# Altium schematic sheet Schem, Transadmittance PWR.SchDoc
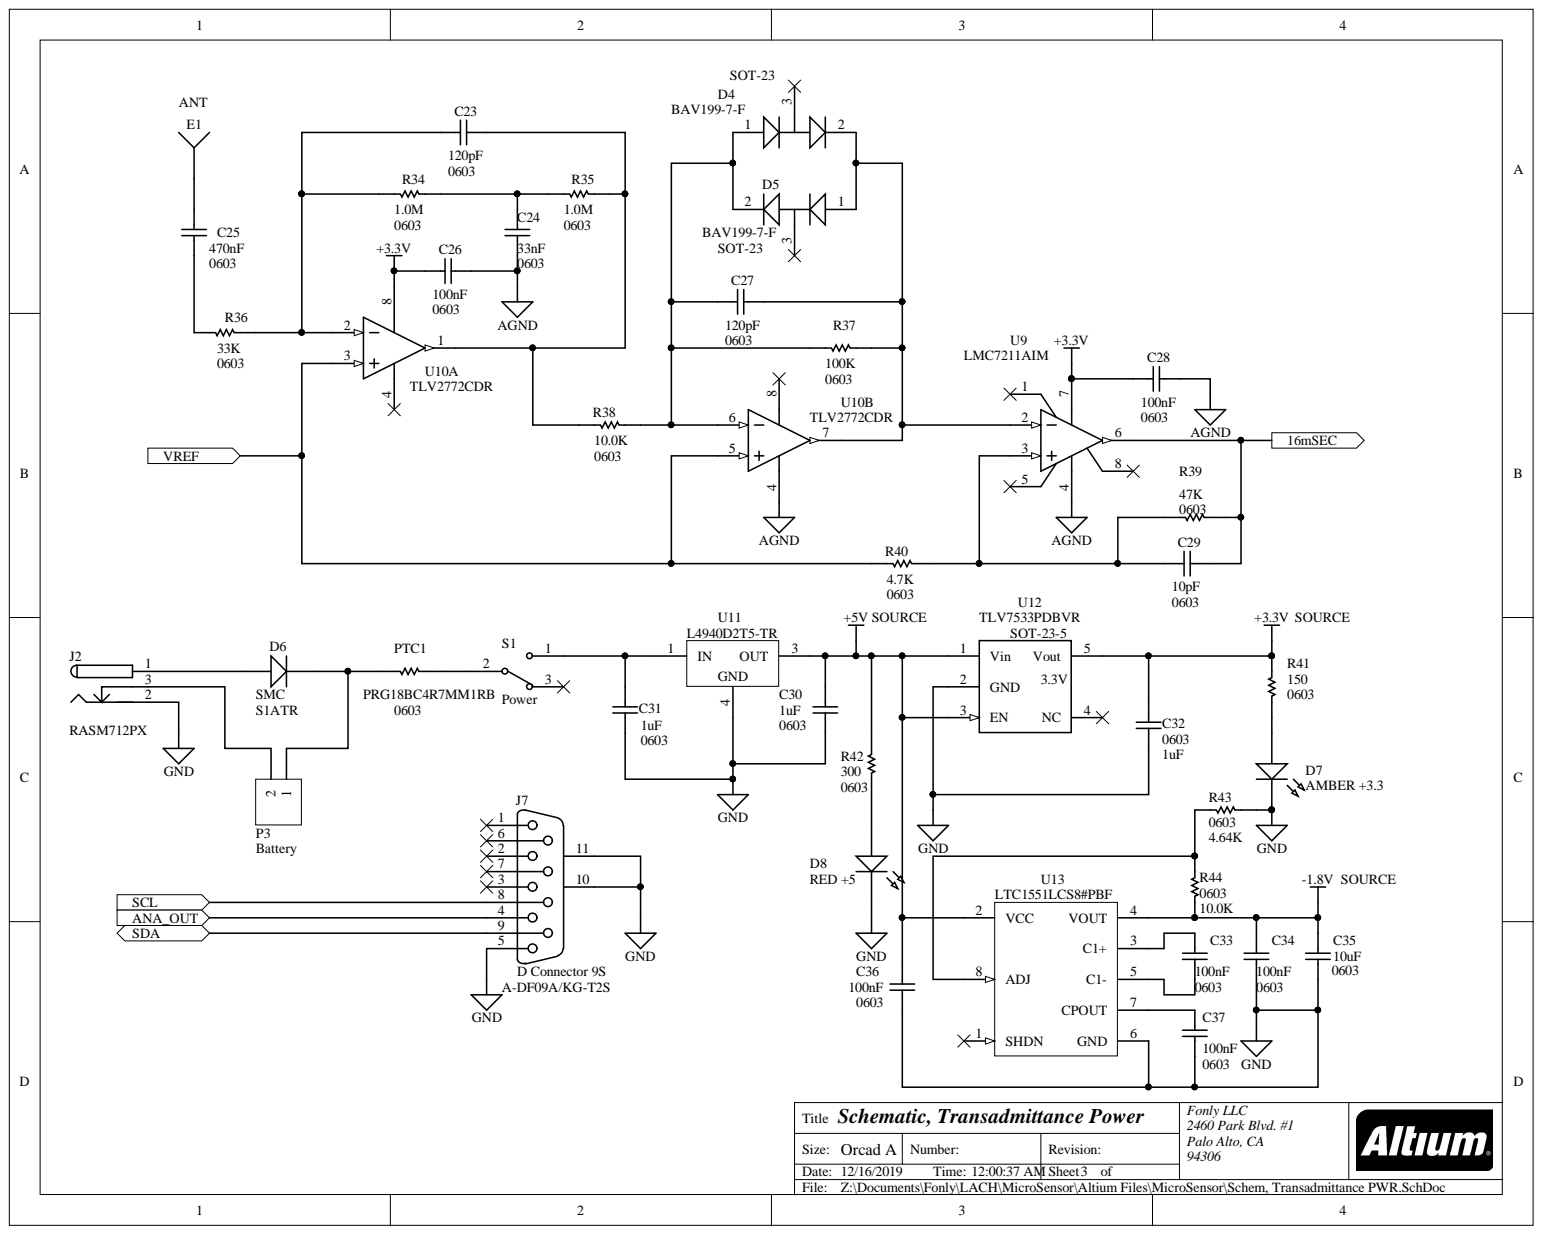
[diagram p0: Schem, Transadmittance PWR.SchDoc]

## Parts
C23  0603  value 120pF  [1608[0603]]
C24  0603  value 33nF  [1608[0603]]
C25  0603  value 470nF  [1608[0603]]
C26  0603  value 100nF  [1608[0603]]
C27  0603  value 120pF  [1608[0603]]
C28  0603  value 100nF  [1608[0603]]
C29  0603  value 10pF  [1608[0603]]
C30  0603  value 1uF  [1608[0603]]
C31  0603  value 1uF  [1608[0603]]
C32  0603  value 1uF  [1608[0603]]
C33  0603  value 100nF  [1608[0603]]
C34  0603  value 100nF  [1608[0603]]
C35  0603  value 10uF  [1608[0603]]
C36  0603  value 100nF  [1608[0603]]
C37  0603  value 100nF  [1608[0603]]
D4  BAV199-7-F  Package / Case: SOT-23  [DIOD-SOT23-3_A_V]
D5  BAV199-7-F  Package / Case: SOT-23  [DIOD-SOT23-3_A_V]
D6  SMC  MFG_P/N: S1ATR  [SMC]
D7  AMBER +3.3  [3.5X2.8X1.9]
D8  RED +5  [3.5X2.8X1.9]
E1  ANT  [PIN1]
J2  MFG_P/N: RASM712PX  [Switchcraft RASM712]  (hidden comment: Switchcraft RASM712)
J7  D Connector 9S  MFG_P/N: A-DF09A/KG-T2S  [DSUB1.385-2H9]
P3  Battery  [HDR1X2]
PTC1  0603  [RESC1608L]
R34  0603  value 1.0M  [RESC1608L]
R35  0603  value 1.0M  [RESC1608L]
R36  0603  value 33K  [RESC1608L]
R37  0603  value 100K  [RESC1608L]
R38  0603  value 10.0K  [RESC1608L]
R39  0603  value 47K  [RESC1608L]
R40  0603  value 4.7K  [RESC1608L]
R41  0603  value 150  [RESC1608L]
R42  0603  value 300  [RESC1608L]
R43  0603  value 4.64K  [RESC1608L]
R44  0603  value 10.0K  [RESC1608L]
S1  Power  [G3T12AH]
U9  LMC7211AIM  [D0008A_M]
U10  TLV2772CDR  [D0008A_N]  (parts A,B)
U11  L4940D2T5-TR  [TO-263_M]
U12  SOT-23-5  value 3.3V  MFG_P/N: TLV7533PDBVR  [DBV005_M]
U13  LTC1551LCS8#PBF  [LT-S8-8_L]

## Ports
16mSEC, ANA_OUT, SCL, SDA, VREF

## Nets
+3.3V: C26.2 C28.2 C32.1 R41.1 U9.7 U10.8 U12.5(Vout)
+5V: C30.1 C36.1 R42.1 U11.3 U12.1(Vin) U12.3(EN) U13.2(VCC)
-1.8V: C34.1 C35.2 R44.2 U13.4(VOUT)
AGND: C24.2 C26.1 C28.1 U9.4 U10.4
GND: C30.2 C31.2 C32.2 C34.2 C35.1 C36.2 C37.2 D7.2 D8.2 J2.2 J7.5 J7.10 J7.11 R43.2 U11.4 U12.2(GND) U13.6(GND)
16mSEC: C29.2 R39.2 U9.6 ; port 16mSEC
ANA_OUT: J7.4 ; port ANA_OUT
SCL: J7.8 ; port SCL
SDA: J7.9 ; port SDA
VREF: R40.2 U10.3 U10.5 ; port VREF
NetC23_1: C23.1 R34.2 R36.1 U10.2
NetC23_2: C23.2 R35.1 R38.2 U10.1
NetC24_1: C24.1 R34.1 R35.2
NetC25_1: C25.1 R36.2
NetC25_2: C25.2 E1.1
NetC27_1: C27.1 D4.1 D5.2 R37.2 R38.1 U10.6
NetC27_2: C27.2 D4.2 D5.1 R37.1 U9.2 U10.7
NetC29_1: C29.1 R39.1 R40.1 U9.3
NetC31_1: C31.1 S1.1 U11.1
NetC33_1: C33.1 U13.3(C1+)
NetC33_2: C33.2 U13.5(C1-)
NetC37_1: C37.1 U13.7(CPOUT)
NetD6_1: D6.1 J2.1
NetD6_2: D6.2 P3.1 PTC1.1
NetD7_1: D7.1 R41.2
NetD8_1: D8.1 R42.2
NetJ2_3: J2.3 P3.2
NetPTC1_2: PTC1.2 S1.2
NetR43_1: R43.1 R44.1 U13.8(ADJ)

Not connected: D4 3; D5 3; J2 2A; J7 1 2 3 6 7; S1 3; U9 1 5 8; U12 4(NC); U13 1(SHDN)

## Library data of the parts
C23 C27 = Cap Semi: Capacitor Ceramic, SMD, 0603, 0.1uF, 16V, 10%; MFG: Samsung; MFG_P/N: C0603C121K5GAC7867; Vendor: DigiKey; Vendor P/N: 399-14288-1-ND; Component Kind: Standard; Library Name: Miscellaneous Devices.SchLib; Signal Integrity: Cap Semi; Simulation: CAP
C24 = Cap Semi: Capacitor Ceramic, SMD, 0603, 0.1uF, 16V, 10%; MFG: Samsung; MFG_P/N: CL10B333KO8NNNC; Vendor: DigiKey; Vendor P/N: 1276-2044-1-ND; Component Kind: Standard; Library Name: Miscellaneous Devices.SchLib; Signal Integrity: Cap Semi; Simulation: CAP
C25 = Cap Semi: Capacitor Ceramic, SMD, 0603, 0.1uF, 16V, 10%; MFG: Samsung; MFG_P/N: CGA3E3X7R1H474M080AB; Vendor: DigiKey; Vendor P/N: 445-181021-1-ND; Component Kind: Standard; Library Name: Miscellaneous Devices.SchLib; Signal Integrity: Cap Semi; Simulation: CAP
C26 = Cap Semi: Capacitor Ceramic, SMD, 0603, 0.1uF, 16V, 10%; MFG: Samsung; MFG_P/N: CL10B104KO8NNWC; Value: 100nF; Vendor: DigiKey; Vendor P/N: 1276-1939-1-ND; Component Kind: Standard; Library Name: Miscellaneous Devices.SchLib; Signal Integrity: Cap Semi; Simulation: CAP
C28 C33 C34 C36 C37 = Cap Semi: Capacitor Ceramic, SMD, 0603, 0.1uF, 16V, 10%; MFG: Samsung; MFG_P/N: CL10B104KO8NNWC; Vendor: DigiKey; Vendor P/N: 1276-1939-1-ND; Component Kind: Standard; Library Name: Miscellaneous Devices.SchLib; Signal Integrity: Cap Semi; Simulation: CAP
C29 = Cap Semi: Capacitor Ceramic, SMD, 0603, 0.1uF, 16V, 10%; MFG: Samsung; MFG_P/N: C0603C100K3GACTU; Vendor: DigiKey; Vendor P/N: 399-8979-1-ND; Component Kind: Standard; Library Name: Miscellaneous Devices.SchLib; Signal Integrity: Cap Semi; Simulation: CAP
C30-C32 = Cap Semi: Capacitor Ceramic, SMD, 0603, .01uF, 16V, 10%; MFG: Kemet; MFG_P/N: C0603C105M3RACTU; Vendor: DigiKey; Vendor P/N: 399-7377-1-ND; Component Kind: Standard; Library Name: Miscellaneous Devices.SchLib; Signal Integrity: Cap Semi; Simulation: CAP
C35 = Cap Semi: Capacitor (Semiconductor SIM Model); MFG: AVX; MFG_P/N: 0603ZD106KAT2A; Vendor: DigiKey; Vendor P/N: 478-10766-1-ND; Component Kind: Standard; Library Name: Miscellaneous Devices.SchLib; Signal Integrity: Cap Semi; Simulation: CAP
D4 D5 = CMP-2000-05931-1: Dual Surface Mount Low Leakage Diode, 1.25 V, -65 to 150 degC, 3-Pin SOT23, RoHS, Tape and Reel; MFG: DiodesIncorporated; Vendor: DigiKey; Vendor_P/N: BAV199-FDICT-ND; Component Kind: Standard; Library Name: Altium Content Vault; MFG_P/N: BAV199-7-F; Package Reference: SOT23-3; Series: BAV199
D6 = Diode: Diode, 1A 50 PIV, SMC Ccase; Vendor: DigiKey; Vendor P/N: 655-1502-1-ND; Component Kind: Standard; Library Name: Miscellaneous Devices.SchLib; MFG: SMC; Signal Integrity: Diode; Simulation: DIODE
D7 = LED3: Amber LED; MFG: Inolux; MFG_P/N: IN-S126ATA; Vendor: DigiKey; Vendor P/N: 1830-1091-1-ND; Component Kind: Standard; Library Name: Miscellaneous Devices.SchLib; Signal Integrity: LED; Simulation: LED3
D8 = LED3: Red LED; MFG: LiteOn; MFG_P/N: LTST-C150KRKT; Vendor: DigiKey; Vendor P/N: 160-1405-1-ND; Component Kind: Standard; Library Name: Miscellaneous Devices.SchLib; Signal Integrity: LED; Simulation: LED3
E1 = Socket: Component Kind: Standard; Description: Socket; LatestRevisionDate: 17-Jul-2002; LatestRevisionNote: Re-released for DXP Platform.; Library Name: Current Miscellaneous Connectors.SchLib; Signal Integrity: Connector; Publisher: Altium Limited
J2 = Switchcraft RASM712: Recp., Pwr, coaxial, barrel, 2.5/2.1mm pin, RASM; Vendor: DigiKey; Vendor P/N: RASM712PX-ND; Component Kind: Standard; Library Name: Current Miscellaneous Connectors.SchLib; MFG: Switchcraft
J7 = D Connector 9: Receptacle Assembly, 9 Position, Right Angle; MFG: Assmann; Vendor: DigiKey; Vendor P/N: AE10921-ND; Component Kind: Standard; Library Name: Current Miscellaneous Connectors.SchLib; Signal Integrity: Connector
P3 = Header 2: Header, 2-Pin, M, 0.1"; MFG: TE; MFG_P/N: 87224-2; Vendor: DigiKey; Vendor_P/N: A26543-ND; Component Kind: Standard; LatestRevisionDate: 17-Jul-2002; LatestRevisionNote: Re-released for DXP Platform.; Library Name: Current Miscellaneous Connectors.SchLib; Signal Integrity: Connector; Publisher: Altium Limited
PTC1 = Res1: PTC thermistor - 155mA hold I, 800mA.; MFG: Murata; MFG_P/N: PRG18BC4R7MM1RB; Value: 4.7ohm; Vendor: DigiKey; Vendor P/N: 490-8507-1-ND; Component Kind: Standard; Library Name: Miscellaneous Devices.SchLib; Signal Integrity: Res; Simulation: RESISTOR
R34 R35 = Res1: Resistor; MFG: Panasonic; MFG_P/N: ERJ-3EKF1004V; Vendor: DigiKey; Vendor P/N: P1.00MHCT-ND; Component Kind: Standard; Library Name: Miscellaneous Devices.SchLib; Signal Integrity: Res; Simulation: RESISTOR
R36 = Res1: Resistor; MFG: Panasonic; MFG_P/N: ERJ-3EKF3302V; Vendor: DigiKey; Vendor P/N: P33.0KHCT-ND; Component Kind: Standard; Library Name: Miscellaneous Devices.SchLib; Signal Integrity: Res; Simulation: RESISTOR
R37 = Res1: Resistor; MFG: Panasonic; MFG_P/N: ERJ-3EKF1003V; Vendor: DigiKey; Vendor P/N: P100KHCT-ND; Component Kind: Standard; Library Name: Miscellaneous Devices.SchLib; Signal Integrity: Res; Simulation: RESISTOR
R38 R44 = Res1: Resistor; MFG: Panasonic; MFG_P/N: ERJ-3EKF1002V; Vendor: DigiKey; Vendor P/N: P10.0KHCT-ND; Component Kind: Standard; Library Name: Miscellaneous Devices.SchLib; Signal Integrity: Res; Simulation: RESISTOR
R39 = Res1: Resistor; MFG: Panasonic; MFG_P/N: ERJ-3EKF4702V; Vendor: DigiKey; Vendor P/N: P47.0KHCT-ND; Component Kind: Standard; Library Name: Miscellaneous Devices.SchLib; Signal Integrity: Res; Simulation: RESISTOR
R40 = Res1: Resistor; MFG: Panasonic; MFG_P/N: ERJ-3EKF1002V; Vendor: DigiKey; Vendor P/N: P4.70KHCT-ND; Component Kind: Standard; Library Name: Miscellaneous Devices.SchLib; Signal Integrity: Res; Simulation: RESISTOR
R41 = Res1: Resistor; MFG: Panasonic; MFG_P/N: ERJ-3EKF1500V; Vendor: DigiKey; Vendor P/N: P150HCT-ND; Component Kind: Standard; Library Name: Miscellaneous Devices.SchLib; Signal Integrity: Res; Simulation: RESISTOR
R42 = Res1: Resistor; MFG: Panasonic; MFG_P/N: ERJ-3EKF3000V; Vendor: DigiKey; Vendor P/N: P300HCT-ND; Component Kind: Standard; Library Name: Miscellaneous Devices.SchLib; Signal Integrity: Res; Simulation: RESISTOR
R43 = Res1: Resistor; MFG: Panasonic; MFG_P/N: ERJ-PA3F4641V; Vendor: DigiKey; Vendor P/N: 667-ERJ-PA3F4641V; Component Kind: Standard; Library Name: Miscellaneous Devices.SchLib; Signal Integrity: Res; Simulation: RESISTOR
S1 = SW-SPDT: SPDT Subminiature Toggle Switch, Right Angle Mounting, Vertical Actuation; MFG: NTT; MFG_P/N: G3T12AH-R; Vendor: DigiKey; Vendor P/N: 360-1773-1-ND; Component Kind: Standard; ComponentLink1Description: Manufacturer Link; ComponentLink1URL: http://www.apem.com; DatasheetVersion: 05-Dec-2000; LatestRevisionDate: 17-Dec-2003; Library Name: Miscellaneous Devices.SchLib
U9 = CMP-0055-00407-3: Tiny CMOS Comparator with Rail-to-Rail Input, 8-pin Narrow SOIC; Manufacturer: Texas Instruments; CompType: Amplifiers; PackageTopMarking: NSZXTT*LMC72*11AIM; PowerWise: N; Code_IPC: SOIC127P600-8; Code_JEDEC: MS-012-AA; Component Kind: Standard; ComponentLink1Description: Datasheet URL; ComponentLink1URL: http://www.ti.com/general/docs/lit/getliterature.tsp?genericPartNumber=LMC7211-N&fileType=pdf; Library Name: Altium Content Vault; PackageDescription: SOIC Narrow, 4.9 x 3.9 x 1.45mm, 8 Lead, 1.27mm Pitch; Package Reference: D0008A; PinCount: 8; RoHS: N
U10 = CMP-0272-03014-3: Dual High-Slew-Rate Rail-to-Rail Output Operational Amplifier, 2.5 to 6 V, 0 to 70 degC, 8-pin SOIC (D8), Green (RoHS & no Sb/Br); CMRR(Min)(dB): 60; GBW(Typ)(MHz): 4.8; Iq per channel(Max)(mA): 2; MFG: TI; Packing: LARGE T&R; Rating: Catalog; Slew Rate(Typ)(V/us): 9; Sub Family: Low Supply Voltage Amplifier (<=2.7V), Precision Amplifier; Total Supply Voltage(Max)(+5V is 5, +/-5V is 10): 6; Total Supply Voltage(Min)(+5V is 5, +/-5V is 10): 2.5; Vendor: DigiKey; Vendor P/N: 296-10677-1-ND; Code_JEDEC: MS-012; Component Kind: Standard; ComponentLink1Description: Manufacturer URL; ComponentLink1URL: http://www.ti.com/; ComponentLink2Description: Datasheet; ComponentLink2URL: http://www.ti.com/lit/ds/symlink/tlv2772.pdf; ComponentLink3Description: Package Specification; ComponentLink3URL: http://www.ti.com/litv/pdf/msoi002j; DatasheetVersion: SLOS209G; Eco-Plan: Green (RoHS & no Sb/Br); IIB(Max)(pA): 60; Library Name: Altium Content Vault; MFG_P/N: TLV2772CDR; Mounting Technology: Surface Mount; Number of Channels: 2; Offset Drift(Typ)(uV/C): 2; PackageDescription: 8-Pin Plastic Small Outline, Body 4.9 x 3.9 mm, Pitch 1.27 mm; PackageReference: D0008A; PackageVersion: 4040047-3/M, 06/2011; PartNumber: TLV2772CDR; Vio (25C)(Max)(mV): 2.5
U11 = CMP-0244-00196-1: 1.5A Very Low Drop Regulator, 3-Pin D2PAK, Tape and Reel; MFG: ST; Vendor: DigiKey; Vendor P/N: 497-1166-1-ND; Component Kind: Standard; ComponentLink1Description: Manufacturer URL; ComponentLink1URL: http://www.st.com; ComponentLink2Description: Datasheet; ComponentLink2URL: http://www.st.com/internet/com/TECHNICAL_RESOURCES/TECHNICAL_LITERATURE/DATASHEET/CD00000442.pdf; Library Name: Altium Content Vault; MFG_P/N: L4940D2T5-TR; PackageReference: TO-263
U12 = TLV755: IC, Voltage Regulator, Linear LDO, SOT-23-5; MFG: TI; Vendor: DigiKey; Vendor P/N: 296-50411-1-ND; Component Kind: Standard; Library Name: TI Power Mgt Voltage Regulator.IntLib
U13 = CMP-0475-00442-1: Low Noise, Switched Capacitor Regulated Voltage Inverter, 2.7 to 5.25 V Vin, Adjustable Vout, 8-pin SOIC (S8-8), 0 to 70 degC, Pb-Free; Manufacturer: Linear Technology; Frequency(kHz): 900; Ishutdown(uA): 0.1; Isupply(mA): 3.65; Max Iout(mA): 20; Vin Max(V): 5.25; Vin Min(V): 2.7; Voutput: Adj; Component Kind: Standard; ComponentLink1Description: Manufacturer URL; ComponentLink1URL: http://www.linear.com/; ComponentLink2Description: Datasheet; ComponentLink2URL: http://cds.linear.com/docs/en/datasheet/15501lf.pdf; ComponentLink3Description: Package Specification; ComponentLink3URL: http://cds.linear.com/docs/en/packaging/05081610_G_SO8.pdf; Library Name: Altium Content Vault; Mounting Technology: Surface Mount; PackageDescription: 8-Pin Plastic Small Outline (Narrow .150 Inch), Body 4.9 x 3.9 mm, Pitch 1.27 mm; PackageReference: S8-8; PackageVersion: Rev. G, 03/2012; PartNumber: LTC1551LCS8#PBF; RoHS: TRUE
